# Altium schematic sheet Chip_Inputs.SchDoc(Chip_Inputs)
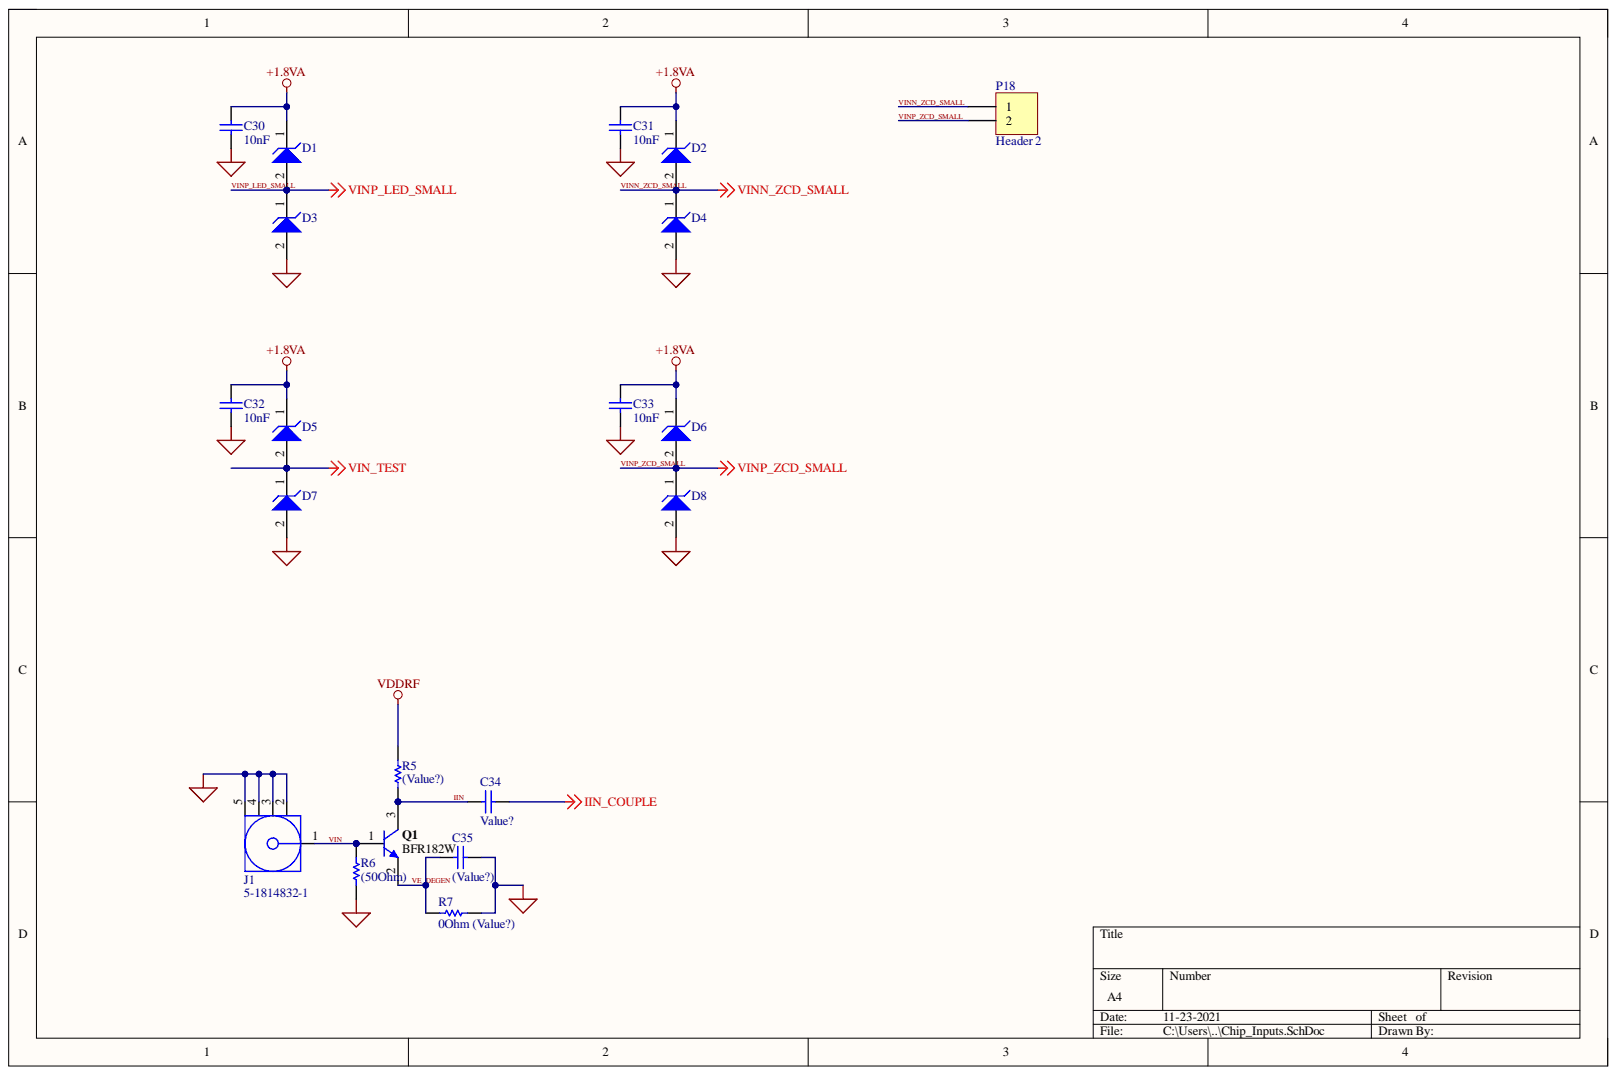
[diagram p0: Chip_Inputs.SchDoc]

## Parts
C30  10nF  [CAPC1608X90X35ML10T15]
C31  10nF  [CAPC1608X90X35ML10T15]
C32  10nF  [CAPC1608X90X35ML10T15]
C33  10nF  [CAPC1608X90X35ML10T15]
C34  Value?  [CAPC1608X90X35ML10T15]
C35  (Value?)  [CAPC1608X90X35ML10T15]
D1  (no value)  [ONSC-SOD-123-2-425-04_V]
D2  (no value)  [ONSC-SOD-123-2-425-04_V]
D3  (no value)  [ONSC-SOD-123-2-425-04_V]
D4  (no value)  [ONSC-SOD-123-2-425-04_V]
D5  (no value)  [ONSC-SOD-123-2-425-04_V]
D6  (no value)  [ONSC-SOD-123-2-425-04_V]
D7  (no value)  [ONSC-SOD-123-2-425-04_V]
D8  (no value)  [ONSC-SOD-123-2-425-04_V]
J1  5-1814832-1  [TECO-5-1814832-1_V]
P18  Header 2  [HDR1X2]
Q1  BFR182W  [INF-SOT323_L]
R5  (Value?)  [RESC1609X50X30NL8T20]
R6  (50Ohm)  [RESC1609X50X30NL8T20]
R7  0Ohm (Value?)  [RESC1609X50X30NL8T20]

## Nets
+1.8VA: C30.2 C31.2 C32.2 C33.2 D1.1 D2.1 D5.1 D6.1
GND: C30.1 C31.1 C32.1 C33.1 C35.1 D3.2 D4.2 D7.2 D8.2 J1.2 J1.3 J1.4 J1.5 R6.1 R7.1
IIN_COUPLE: C34.1
VDDRF: R5.2
VIN_TEST: D5.2 D7.1
IIN: C34.2 Q1.3 R5.1
VE_DEGEN: C35.2 Q1.2 R7.2
VIN: J1.1 Q1.1 R6.2
VINN_ZCD_SMALL: D2.2 D4.1 P18.1
VINP_LED_SMALL: D1.2 D3.1
VINP_ZCD_SMALL: D6.2 D8.1 P18.2

## Library data of the parts
C30-C35 = CMP-2000-04945-1: 0603; Manufacturer: Murata; Capacitance: 22pF; Dielectric: C0G; Rated Voltage: 50V; Tolerance (%): ±5%; Case Size/Dimension: 0603; Component Kind: Standard; Datasheet URL: https://datasheet.ciiva.com/11786/0900766b807a1cab-11786398.pdf; Datasheet Version: Jul-06; Library Name: Altium Content Vault; Manufacturer URL: http://www.murata.com/; Package Description: 2-Pin Surface Mount Device, Body 1.6 x 0.8 mm; Package Reference: 603
D1-D8 = CMP-1060-00509-1: Zener Voltage Regulator, 2-Pin SOD-123, Pb-Free, Tape and Reel; Manufacturer: On Semiconductor; PartNumber: MMSZ4679T1G; P Max (W): 0.5; VZ Typ (V): 2; Component Kind: Standard; ComponentLink1Description: Manufacturer URL; ComponentLink1URL: http://www.onsemi.com/; ComponentLink2Description: Datasheet; ComponentLink2URL: http://www.onsemi.com/pub_link/Collateral/MMSZ4678T1-D.PDF; ComponentLink3Description: Package Specification; ComponentLink3URL: http://www.onsemi.com/pub_link/Collateral/425-04.PDF; DatasheetVersion: Rev. 9; Library Name: Altium Content Vault; Mounting Technology: Surface Mount; PackageDescription: 2-Pin SOD, Body 1.6 x 2.69 mm; PackageReference: SOD-123-2-425-04; PackageVersion: Rev. G, 10/2009; Packing: Tape and Reel; RoHS: Pb-Free
J1 = CMP-2000-05415-1: SMA Connector, Pitch 5.08 mm, 1 Position, Height 9.5 mm, Tail Length 4 mm, -65 to 165 degC, RoHS, Bulk; Manufacturer: TE Connectivity; Coaxial Termination: Solder; Connector Body Style: StraightJack; Connector Mounting: PCBMount; Connector Type: SMACoaxial; Frequency Max: 3GHz; Impedance: 50ohm; Product Range: ZDCSeries; SVHC: NoSVHC(15-Jun-2015); Coaxial Cable Types: -; Component Kind: Standard; Contact Material: BerylliumCopper; Contact Plating: GoldPlatedContacts; Datasheet URL: http://www.onlinecomponents.com/productFiles/mf-AMP/eng_cd_1814832_a.pdf; Datasheet Version: Rev. B1, 03/2011; Library Name: Altium Content Vault; Manufacturer URL: http://www.te.com/; Package Description: SMA Connector, Pitch 5.08 mm, 1 Position, Height 9.5 mm, Tail Length 4 mm; Package Reference: 5-1814832-1
P18 = Header 2: Header, 2-Pin; Component Kind: Standard; LatestRevisionDate: 17-Jul-2002; LatestRevisionNote: Re-released for DXP Platform.; Library Name: Miscellaneous Connectors.IntLib; Signal Integrity: Connector; Publisher: Altium Limited
Q1 = CMP-1191-00013-1: NPN Silicon RF Transistor, 12 V VCEO, 35 mA IC, 19 dB Gmax, SOT323, Green; Manufacturer: Infineon; Gmax (typ): 19 dB; Green: yes; Group: For low frequencies e.g. VHF/UHF; IC (max): 35 mA; NFmin (typ): 0.9 dB; Ptot (max): 250mW; VCEO (max): 12 V; Component Kind: Standard; ComponentLink1Description: Manufacturer URL; ComponentLink1URL: http://www.infineon.com/; ComponentLink2Description: Datasheet; ComponentLink2URL: http://www.infineon.com/dgdl/bfr182w.pdf?folderId=db3a30431400ef68011425b1354605c1&fileId=db3a30431400ef680114269d1e43064c&location=en.Products.RF.RF_Transistors_.Low_Noise_Si_Transistors_up_to_2_5_GHz.DATASHEET.bfr182w.pdf; ComponentLink3Description: Package Specification; ComponentLink3URL: http://www.infineon.com/dgdl/SOT323-Package_Overview.pdf?folderId=db3a30431936bc4b0119533e1dcb3c92&fileId=db3a30431936bc4b011953495f283cb1; DatasheetVersion: Apr-2010; Halogen-free: yes; Library Name: Altium Content Vault; Mounting Technology: Surface Mount; PackageDescription: 3-Pin Small Outline Transistor Package, 1.25 x 2 mm Body, 0.65 mm Pitch; PackageReference: SOT323; PartNumber: BFR182W
R5-R7 = CMP-2000-03816-5: Chip Resistor, 0 Ohm, Jumper, 100 mW, -55 to 155 degC, 0603 (1608 Metric), RoHS, Tape and Reel; Manufacturer: Vishay Semiconductor; Voltage Rating: 75 V; Component Kind: Standard; ComponentLink1Description: Manufacturer URL; ComponentLink1URL: http://www.vishay.com/; ComponentLink2Description: Datasheet; ComponentLink2URL: http://www.vishay.com/docs/20035/dcrcwe3.pdf; DatasheetVersion: 06/2012; Height: 0.45 mm; Length: 1.55 mm; Library Name: Altium Content Vault; Max Operating Temperature: 155 degC; Min Operating Temperature: -55 degC; Mount: Surface Mount; Mounting Technology: Surface Mount; Package Quantity: 5000; PackageDescription: 2-Pin Surface Mount Device, Body 1.575 x 0.85 mm; PackageReference: 0603; Packaging: Tape and Reel; Pins: 2; REACH SVHC: Unknown; RoHSCompliant: true
